# Altium schematic sheet 04 - Peripherals.SchDoc
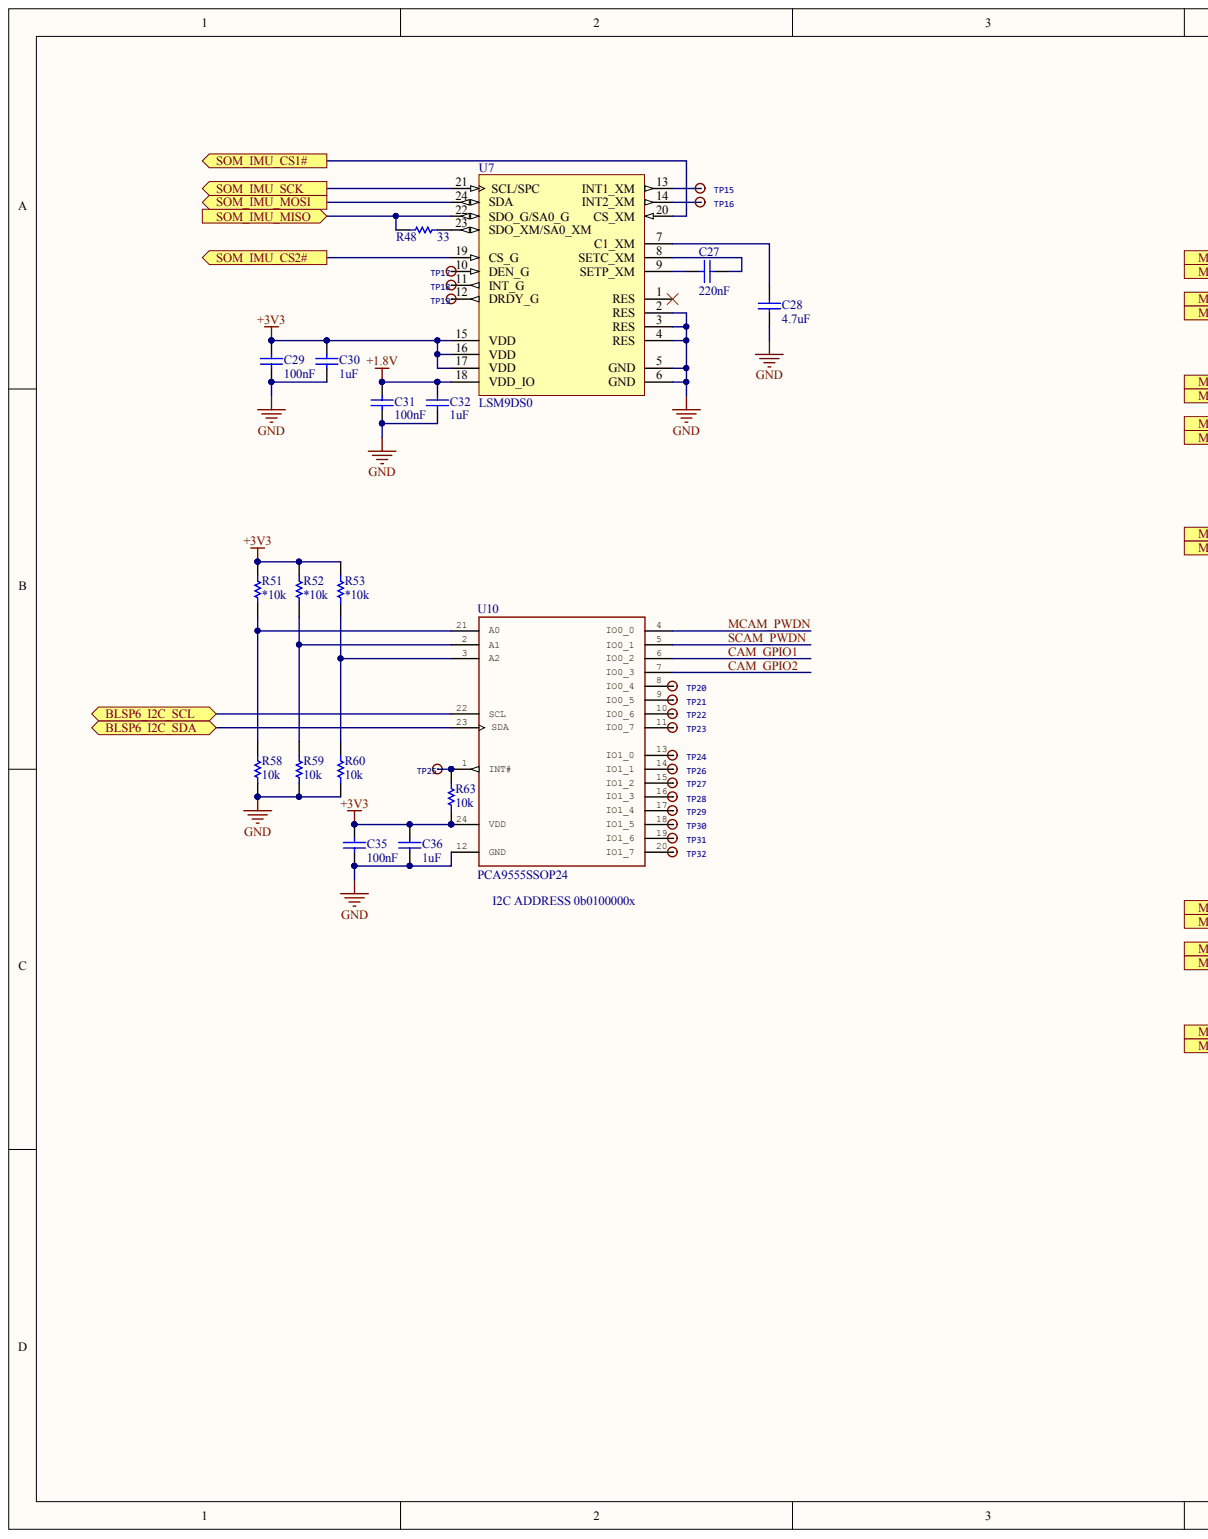
[diagram p0: 04 - Peripherals.SchDoc, left]
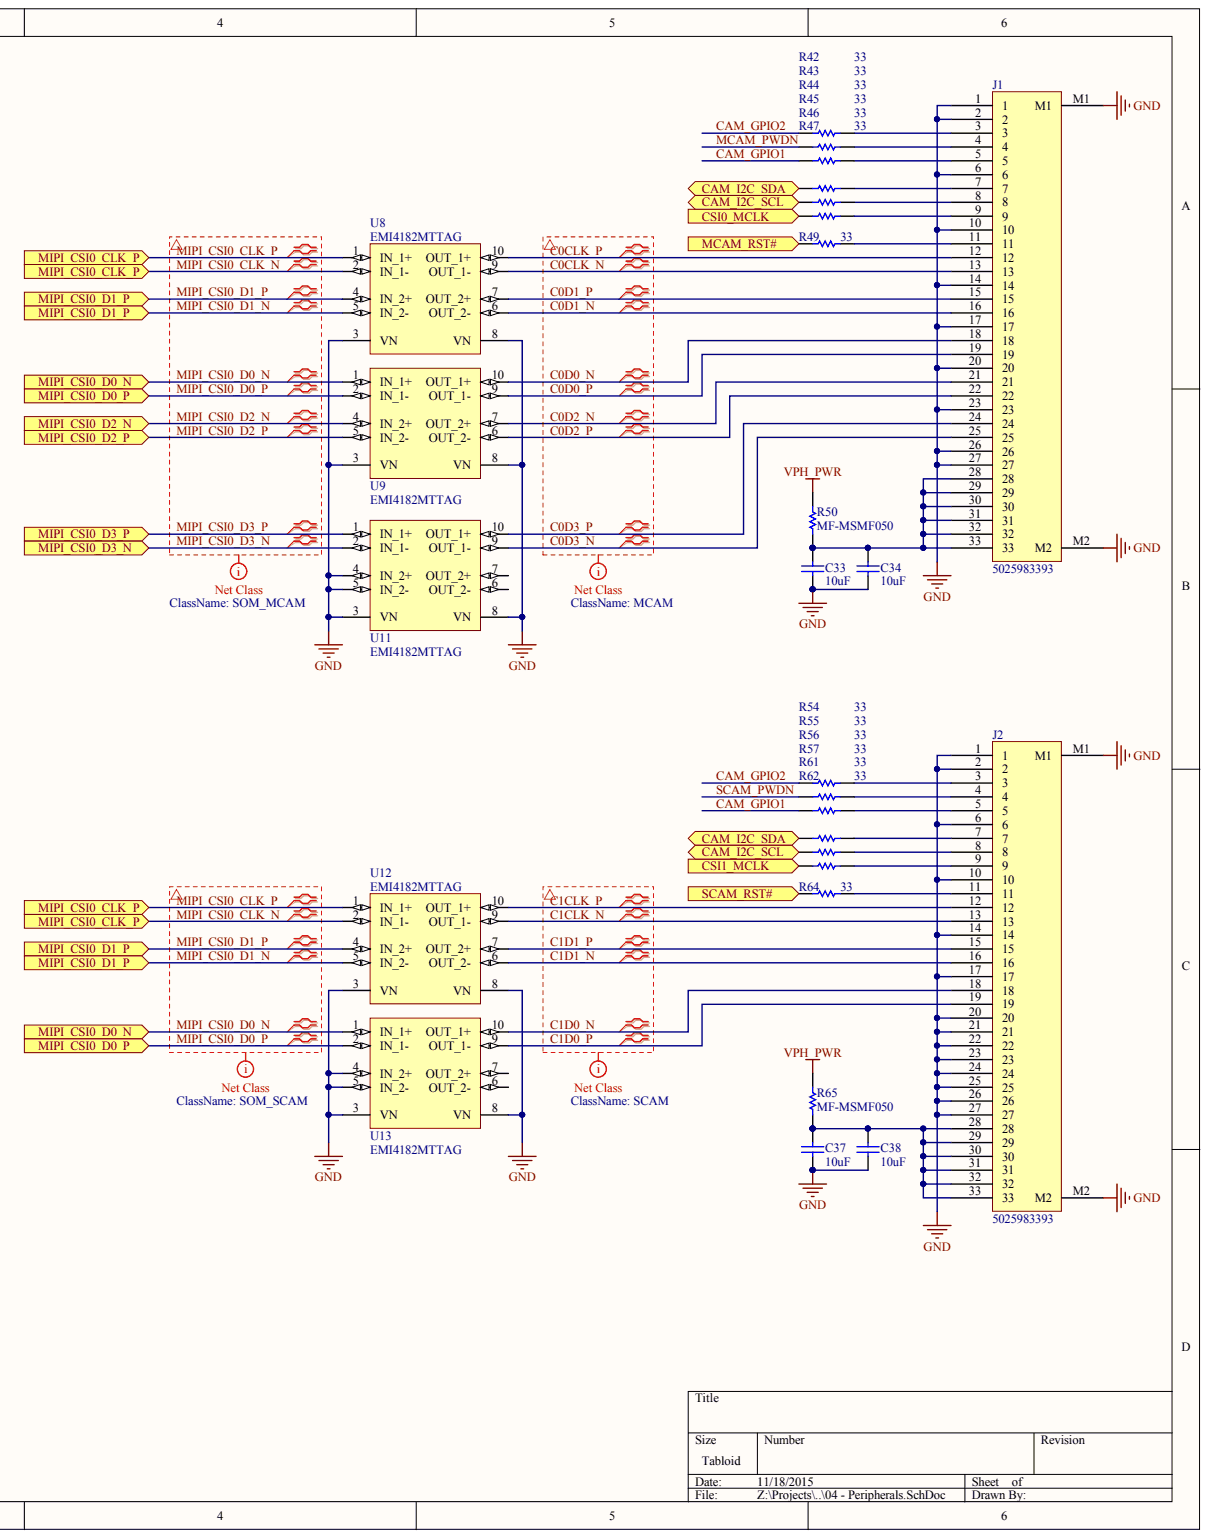
[diagram p1: 04 - Peripherals.SchDoc, right]

## Parts
C27  value 220nF  [CAPC0805(2012)100_L]
C28  value 4.7uF  [CAPC0805(2012)100_M]  (hidden comment: CAP 4.7uF 6.3V 0805(2012))
C29  value 100nF  [CAPC0805(2012)100_N]  (hidden comment: CAP 100nF 6.3V 0805(2012))
C30  value 1uF  [CAPC0805(2012)100_M]  (hidden comment: CAP 1uF 6.3V 0805(2012))
C31  value 100nF  [CAPC0805(2012)100_N]  (hidden comment: CAP 100nF 6.3V 0805(2012))
C32  value 1uF  [CAPC0805(2012)100_M]  (hidden comment: CAP 1uF 6.3V 0805(2012))
C33  value 10uF  [CAPC0805(2012)100_L]  (hidden comment: CAP 10uF 6.3V 0805(2012))
C34  value 10uF  [CAPC0805(2012)100_L]  (hidden comment: CAP 10uF 6.3V 0805(2012))
C35  value 100nF  [CAPC0805(2012)100_N]  (hidden comment: CAP 100nF 6.3V 0805(2012))
C36  value 1uF  [CAPC0805(2012)100_M]  (hidden comment: CAP 1uF 6.3V 0805(2012))
C37  value 10uF  [CAPC0805(2012)100_L]  (hidden comment: CAP 10uF 6.3V 0805(2012))
C38  value 10uF  [CAPC0805(2012)100_L]  (hidden comment: CAP 10uF 6.3V 0805(2012))
J1  5025983393
J2  5025983393
R42  value 33  [RESC0805(2012)_L]  (hidden comment: 33R 1% 0805(2012))
R43  value 33  [RESC0805(2012)_L]  (hidden comment: 33R 1% 0805(2012))
R44  value 33  [RESC0805(2012)_L]  (hidden comment: 33R 1% 0805(2012))
R45  value 33  [RESC0805(2012)_L]  (hidden comment: 33R 1% 0805(2012))
R46  value 33  [RESC0805(2012)_L]  (hidden comment: 33R 1% 0805(2012))
R47  value 33  [RESC0805(2012)_L]  (hidden comment: 33R 1% 0805(2012))
R48  value 33  [RESC0805(2012)_L]  (hidden comment: 33R 1% 0805(2012))
R49  value 33  [RESC0805(2012)_L]  (hidden comment: 33R 1% 0805(2012))
R50  MF-MSMF050  [BOUR-MF-MSMF050-2_V]
R51  value *10k  [RESC0805(2012)_M]  (hidden comment: 100k)
R52  value *10k  [RESC0805(2012)_M]  (hidden comment: 100k)
R53  value *10k  [RESC0805(2012)_M]  (hidden comment: 100k)
R54  value 33  [RESC0805(2012)_L]  (hidden comment: 33R 1% 0805(2012))
R55  value 33  [RESC0805(2012)_L]  (hidden comment: 33R 1% 0805(2012))
R56  value 33  [RESC0805(2012)_L]  (hidden comment: 33R 1% 0805(2012))
R57  value 33  [RESC0805(2012)_L]  (hidden comment: 33R 1% 0805(2012))
R58  value 10k  [RESC0805(2012)_M]  (hidden comment: 100k)
R59  value 10k  [RESC0805(2012)_M]  (hidden comment: 100k)
R60  value 10k  [RESC0805(2012)_M]  (hidden comment: 100k)
R61  value 33  [RESC0805(2012)_L]  (hidden comment: 33R 1% 0805(2012))
R62  33R 1% 0805(2012)  [RESC0805(2012)_L]  (value not drawn)
R63  10k  [RESC0805(2012)_M]
R64  value 33  [RESC0805(2012)_L]  (hidden comment: 33R 1% 0805(2012))
R65  MF-MSMF050  [BOUR-MF-MSMF050-2_V]
TP15  (no value)  [TESTPOINT_035HOLE]
TP16  (no value)  [TESTPOINT_035HOLE]
TP17  (no value)  [TESTPOINT_035HOLE]
TP18  (no value)  [TESTPOINT_035HOLE]
TP19  (no value)  [TESTPOINT_035HOLE]
TP20  (no value)  [TESTPOINT_035HOLE]
TP21  (no value)  [TESTPOINT_035HOLE]
TP22  (no value)  [TESTPOINT_035HOLE]
TP23  (no value)  [TESTPOINT_035HOLE]
TP24  (no value)  [TESTPOINT_035HOLE]
TP25  (no value)  [TESTPOINT_035HOLE]
TP26  (no value)  [TESTPOINT_035HOLE]
TP27  (no value)  [TESTPOINT_035HOLE]
TP28  (no value)  [TESTPOINT_035HOLE]
TP29  (no value)  [TESTPOINT_035HOLE]
TP30  (no value)  [TESTPOINT_035HOLE]
TP31  (no value)  [TESTPOINT_035HOLE]
TP32  (no value)  [TESTPOINT_035HOLE]
U7  LSM9DS0  [STM-LGA-24_V]
U8  EMI4182MTTAG  [ONSC-WDFN-10-511BM-01_V]
U9  EMI4182MTTAG  [ONSC-WDFN-10-511BM-01_V]
U10  PCA9555SSOP24  [SSOP24]
U11  EMI4182MTTAG  [ONSC-WDFN-10-511BM-01_V]
U12  EMI4182MTTAG  [ONSC-WDFN-10-511BM-01_V]
U13  EMI4182MTTAG  [ONSC-WDFN-10-511BM-01_V]

## Ports
BLSP6_I2C_SCL, BLSP6_I2C_SDA, CAM_I2C_SCL, CAM_I2C_SDA, CSI0_MCLK, CSI1_MCLK, MCAM_RST#, MIPI_CSI0_CLK_P, MIPI_CSI0_D0_N, MIPI_CSI0_D0_P, MIPI_CSI0_D1_P, MIPI_CSI0_D2_N, MIPI_CSI0_D2_P, MIPI_CSI0_D3_N, MIPI_CSI0_D3_P, SCAM_RST#, SOM_IMU_CS1#, SOM_IMU_CS2#, SOM_IMU_MISO, SOM_IMU_MOSI, SOM_IMU_SCK

## Nets
+1.8V: C31.1 C32.1 U7.18(VDD_IO)
+3V3: C29.1 C30.1 C35.1 C36.1 R51.2 R52.2 R53.2 R63.1 U7.15(VDD) U7.16(VDD) U7.17(VDD) U10.24(VDD)
GND: C28.1 C29.2 C30.2 C31.2 C32.2 C33.1 C34.1 C35.2 C36.2 C37.1 C38.1 J1.1 J1.2 J1.6 J1.10 J1.14 J1.17 J1.20 J1.23 J1.26 J1.27 J1.M1 J1.M2 J2.1 J2.2 J2.6 J2.10 J2.14 J2.17 J2.20 J2.21 J2.22 J2.23 J2.24 J2.25 J2.26 J2.27 J2.M1 J2.M2 R58.1 R59.1 R60.1 U7.2(RES) U7.3(RES) U7.4(RES) U7.5(GND) U7.6(GND) U8.3(VN) U8.8(VN) U9.3(VN) U9.8(VN) U10.12(GND) U11.3(VN) U11.4(IN_2+) U11.5(IN_2-) U11.8(VN) U12.3(VN) U12.8(VN) U13.3(VN) U13.4(IN_2+) U13.5(IN_2-) U13.8(VN)
VPH_PWR: R50.2 R65.2
BLSP6_I2C_SCL: U10.22(SCL) ; port BLSP6_I2C_SCL
BLSP6_I2C_SDA: U10.23(SDA) ; port BLSP6_I2C_SDA
C0CLK_N: J1.13 U8.9(OUT_1-)
C0CLK_P: J1.12 U8.10(OUT_1+)
C0D0_N: J1.18 U9.10(OUT_1+)
C0D0_P: J1.19 U9.9(OUT_1-)
C0D1_N: J1.16 U8.6(OUT_2-)
C0D1_P: J1.15 U8.7(OUT_2+)
C0D2_N: J1.21 U9.7(OUT_2+)
C0D2_P: J1.22 U9.6(OUT_2-)
C0D3_N: J1.25 U11.9(OUT_1-)
C0D3_P: J1.24 U11.10(OUT_1+)
C1CLK_N: J2.13 U12.9(OUT_1-)
C1CLK_P: J2.12 U12.10(OUT_1+)
C1D0_N: J2.18 U13.10(OUT_1+)
C1D0_P: J2.19 U13.9(OUT_1-)
C1D1_N: J2.16 U12.6(OUT_2-)
C1D1_P: J2.15 U12.7(OUT_2+)
CAM_GPIO1: R44.1 R56.1 U10.6(IO0_2)
CAM_GPIO2: R42.1 R54.1 U10.7(IO0_3)
CAM_I2C_SCL: R46.1 R61.1 ; port CAM_I2C_SCL
CAM_I2C_SDA: R45.1 R57.1 ; port CAM_I2C_SDA
CSI0_MCLK: R47.1 ; port CSI0_MCLK
CSI1_MCLK: R62.1 ; port CSI1_MCLK
MCAM_PWDN: R43.1 U10.4(IO0_0)
MCAM_RST#: R49.1 ; port MCAM_RST#
MIPI_CSI0_CLK_N: U8.1(IN_1+) U8.2(IN_1-) U12.1(IN_1+) U12.2(IN_1-) ; port MIPI_CSI0_CLK_P
MIPI_CSI0_D0_N: U9.1(IN_1+) U13.1(IN_1+) ; port MIPI_CSI0_D0_N
MIPI_CSI0_D0_P: U9.2(IN_1-) U13.2(IN_1-) ; port MIPI_CSI0_D0_P
MIPI_CSI0_D1_N: U8.4(IN_2+) U8.5(IN_2-) U12.4(IN_2+) U12.5(IN_2-) ; port MIPI_CSI0_D1_P
MIPI_CSI0_D2_N: U9.4(IN_2+) ; port MIPI_CSI0_D2_N
MIPI_CSI0_D2_P: U9.5(IN_2-) ; port MIPI_CSI0_D2_P
MIPI_CSI0_D3_N: U11.2(IN_1-) ; port MIPI_CSI0_D3_N
MIPI_CSI0_D3_P: U11.1(IN_1+) ; port MIPI_CSI0_D3_P
SCAM_PWDN: R55.1 U10.5(IO0_1)
SCAM_RST#: R64.1 ; port SCAM_RST#
SOM_IMU_CS1#: U7.20(CS_XM) ; port SOM_IMU_CS1#
SOM_IMU_CS2#: U7.19(CS_G) ; port SOM_IMU_CS2#
SOM_IMU_MISO: R48.1 U7.22(SDO_G/SA0_G) ; port SOM_IMU_MISO
SOM_IMU_MOSI: U7.24(SDA) ; port SOM_IMU_MOSI
SOM_IMU_SCK: U7.21(SCL/SPC) ; port SOM_IMU_SCK
NetC27_1: C27.1 U7.9(SETP_XM)
NetC27_2: C27.2 U7.8(SETC_XM)
NetC28_2: C28.2 U7.7(C1_XM)
NetC33_2: C33.2 C34.2 J1.28 J1.29 J1.30 J1.31 J1.32 J1.33 R50.1
NetC37_2: C37.2 C38.2 J2.28 J2.29 J2.30 J2.31 J2.32 J2.33 R65.1
NetJ1_3: J1.3 R42.2
NetJ1_4: J1.4 R43.2
NetJ1_5: J1.5 R44.2
NetJ1_7: J1.7 R45.2
NetJ1_8: J1.8 R46.2
NetJ1_9: J1.9 R47.2
NetJ1_11: J1.11 R49.2
NetJ2_3: J2.3 R54.2
NetJ2_4: J2.4 R55.2
NetJ2_5: J2.5 R56.2
NetJ2_7: J2.7 R57.2
NetJ2_8: J2.8 R61.2
NetJ2_9: J2.9 R62.2
NetJ2_11: J2.11 R64.2
NetR48_2: R48.2 U7.23(SDO_XM/SA0_XM)
NetR51_1: R51.1 R58.2 U10.21(A0)
NetR52_1: R52.1 R59.2 U10.2(A1)
NetR53_1: R53.1 R60.2 U10.3(A2)
NetR63_2: R63.2 TP25.1 U10.1(INT#)
NetTP15_1: TP15.1 U7.13(INT1_XM)
NetTP16_1: TP16.1 U7.14(INT2_XM)
NetTP17_1: TP17.1 U7.10(DEN_G)
NetTP18_1: TP18.1 U7.11(INT_G)
NetTP19_1: TP19.1 U7.12(DRDY_G)
NetTP20_1: TP20.1 U10.8(IO0_4)
NetTP21_1: TP21.1 U10.9(IO0_5)
NetTP22_1: TP22.1 U10.10(IO0_6)
NetTP23_1: TP23.1 U10.11(IO0_7)
NetTP24_1: TP24.1 U10.13(IO1_0)
NetTP26_1: TP26.1 U10.14(IO1_1)
NetTP27_1: TP27.1 U10.15(IO1_2)
NetTP28_1: TP28.1 U10.16(IO1_3)
NetTP29_1: TP29.1 U10.17(IO1_4)
NetTP30_1: TP30.1 U10.18(IO1_5)
NetTP31_1: TP31.1 U10.19(IO1_6)
NetTP32_1: TP32.1 U10.20(IO1_7)
N00224:
N00225:
N00226:
N00227:

Not connected: U7 1(RES); U11 6(OUT_2-) 7(OUT_2+); U13 6(OUT_2-) 7(OUT_2+)

## Library data of the parts
C27 = CMP-1036-04520-1: CAP 220nF 6.3V Â±20% 0805 (2012 Metric) Thickness 1mm SMD; Case-EIA: 0805; Case-Metric: 2012; Component Kind: Standard; Library Name: Altium Content Vault; Max Thickness: 1 mm; Rated Voltage: 6.3 V; Technology: SMT; Tolerance: Â±20%
C28 = CMP-1036-04879-1: CAP 4.7uF 6.3V Â±20% 0805 (2012 Metric) Thickness 1mm SMD; Case-EIA: 0805; Case-Metric: 2012; Component Kind: Standard; Library Name: Altium Content Vault; Max Thickness: 1 mm; Rated Voltage: 6.3 V; Technology: SMT; Tolerance: Â±20%
C29 C31 C35 = CMP-1036-04391-1: CAP 100nF 6.3V Â±10% 0805 (2012 Metric) Thickness 1mm SMD; Case-EIA: 0805; Case-Metric: 2012; Component Kind: Standard; Library Name: Altium Content Vault; Max Thickness: 1 mm; Rated Voltage: 6.3 V; Technology: SMT; Tolerance: Â±10%
C30 C32 C36 = CMP-1036-04730-1: CAP 1uF 6.3V Â±5% 0805 (2012 Metric) Thickness 1mm SMD; Case-EIA: 0805; Case-Metric: 2012; Component Kind: Standard; Library Name: Altium Content Vault; Max Thickness: 1 mm; Rated Voltage: 6.3 V; Technology: SMT; Tolerance: Â±5%
C33 C34 C37 C38 = CMP-1036-04917-1: CAP 10uF 6.3V Â±10% 0805 (2012 Metric) Thickness 1mm SMD; Case-EIA: 0805; Case-Metric: 2012; Component Kind: Standard; Library Name: Altium Content Vault; Max Thickness: 1 mm; Rated Voltage: 6.3 V; Technology: SMT; Tolerance: Â±10%
R42-R46 R48 R49 R54-R57 R61 R64 = CMP-1013-00341-1: 33R 0.125W 1% 0805 (2012 Metric)  SMD; Case-EIA: 0805; Case-Metric: 2012; Component Kind: Standard; Library Name: Altium Content Vault; Power: 0.125 W; Technology: SMT; Tolerance: 1 %
R47 R62 = CMP-1013-00341-1: 33R 0.125W 1% 0805 (2012 Metric)  SMD; Case-EIA: 0805; Case-Metric: 2012; Value: 33; Component Kind: Standard; Library Name: Altium Content Vault; Power: 0.125 W; Technology: SMT; Tolerance: 1 %
R50 R65 = CMP-0280-00002-2: MF-MSMF Series - PTC Resettable Fuse, 15 V Max, 0.15 Ohm Min, -40 to 85 degC, RoHS, Reel; Manufacturer: Bourns; Current Rating (Max): 100 A; Hold Current: 0.5 A; Trip Current: 1 A; Voltage DC (Max): 15 V; Component Kind: Standard; ComponentLink1Description: Manufacturer URL; ComponentLink1URL: http://www.bourns.com/; ComponentLink2Description: Datasheet; ComponentLink2URL: http://www.bourns.com/data/global/pdfs/mfmsmf.pdf; ComponentLink3Description: Package Specification; ComponentLink3URL: http://www.bourns.com/data/global/pdfs/mfmsmf.pdf; DatasheetVersion: Rev. AD; Library Name: Altium Content Vault; Mounting Technology: Surface Mount; Operating Temperature Range: -40 to 85 degC; PackageDescription: 2-Pin SMD, Body 4.55 x 3.24 mm; PackageReference: MF-MSMF050; Packing: Reel; PartNumber: MF-MSMF050; Resistance (Min): 0.15 Ohm; RoHS: TRUE
R51-R53 R58-R60 = CMP-1013-00623-1: 10K 0.125W 1% 0805 (2012 Metric)  SMD; Case-EIA: 0805; Case-Metric: 2012; Component Kind: Standard; Library Name: Altium Content Vault; Power: 0.125 W; Technology: SMT; Tolerance: 1 %
R63 = CMP-1013-00623-1: Case-EIA: 0805; Case-Metric: 2012; Power: 0.125 W; Tolerance: 1 %; Component Kind: Standard; Library Name: Altium Content Vault; Technology: SMT; Value: 10k
TP15-TP32 = TESTPOINTHOLE035: Component Kind: Standard; Library Name: ak-misc.SCHLIB
U7 = CMP-1273-00001-1: INEMO Inertial Module: 3D Accelerometer, 3D Gyroscope, 3D Magnetometer, 2.4 to 3.6 V, -40 to 85 degC, 24-Pin LGA, RoHS, Tray; Manufacturer: STMicroelectronics; Bandwidth: 400 kHz; IDD_G: 6.1 mA Typ.; IDD_G_LP: 2 mA Typ.; IDD_PDN: 6 uA Typ.; IDD_XM: 350 uA Typ.; VDDIO: 1.71 V Min.; Component Kind: Standard; ComponentLink1Description: Manufacturer URL; ComponentLink1URL: http://www.st.com/; ComponentLink2Description: Datasheet; ComponentLink2URL: http://www.st.com/st-web-ui/static/active/en/resource/technical/document/datasheet/DM00087365.pdf; ComponentLink3Description: Package Specification; ComponentLink3URL: http://www.st.com/st-web-ui/static/active/en/resource/technical/document/datasheet/DM00087365.pdf; DatasheetVersion: Rev. 2; Library Name: Altium Content Vault; Mounting Technology: Surface Mount; Operating Temperature Range: -40 to 85 degC; PackageDescription: 24-Pin Land Grid Array, Body 4 x 4 mm, Pitch 0.5 mm; Packing: Tray; PartNumber: LSM9DS0; RoHS: TRUE; VDD: 2.4 to 3.6 V
U8 U9 U11-U13 = CMP-1066-00059-1: Common Mode Filter with ESD Protection, -40 to 85 degC, 10-Pin WDFN, Pb-Free, Tape and Reel; Manufacturer: On Semiconductor; C Typ (pF): 0.8; Number of Lines: 4; VRWM Max (V): 5; Component Kind: Standard; ComponentLink1Description: Manufacturer URL; ComponentLink1URL: http://www.onsemi.com/; ComponentLink2Description: Datasheet; ComponentLink2URL: http://www.onsemi.cn/pub_link/Collateral/EMI4182-D.PDF; ComponentLink3Description: Package Specification; ComponentLink3URL: http://www.onsemi.cn/pub_link/Collateral/511BM.PDF; DatasheetVersion: Rev. 1; Library Name: Altium Content Vault; Mounting Technology: Surface Mount; PackageDescription: 10-Pin Small Outline No-Lead, Body 2.5 x 2 mm, Pitch 0.5 mm; PackageReference: WDFN-10-511BM-01; PackageVersion: Rev. O, 06/2010; Packing: Tape and Reel; PartNumber: EMI4182MTTAG; RoHS: Pb-Free
J1 J2 = 5025983393: Component Kind: Standard; Library Name: q410som.SchLib
U10 = PCA9555SSOP24: Component Kind: Standard; Library Name: ak-misc.IntLib
